# Altium schematic sheet Sch_Harvesting.SchDoc
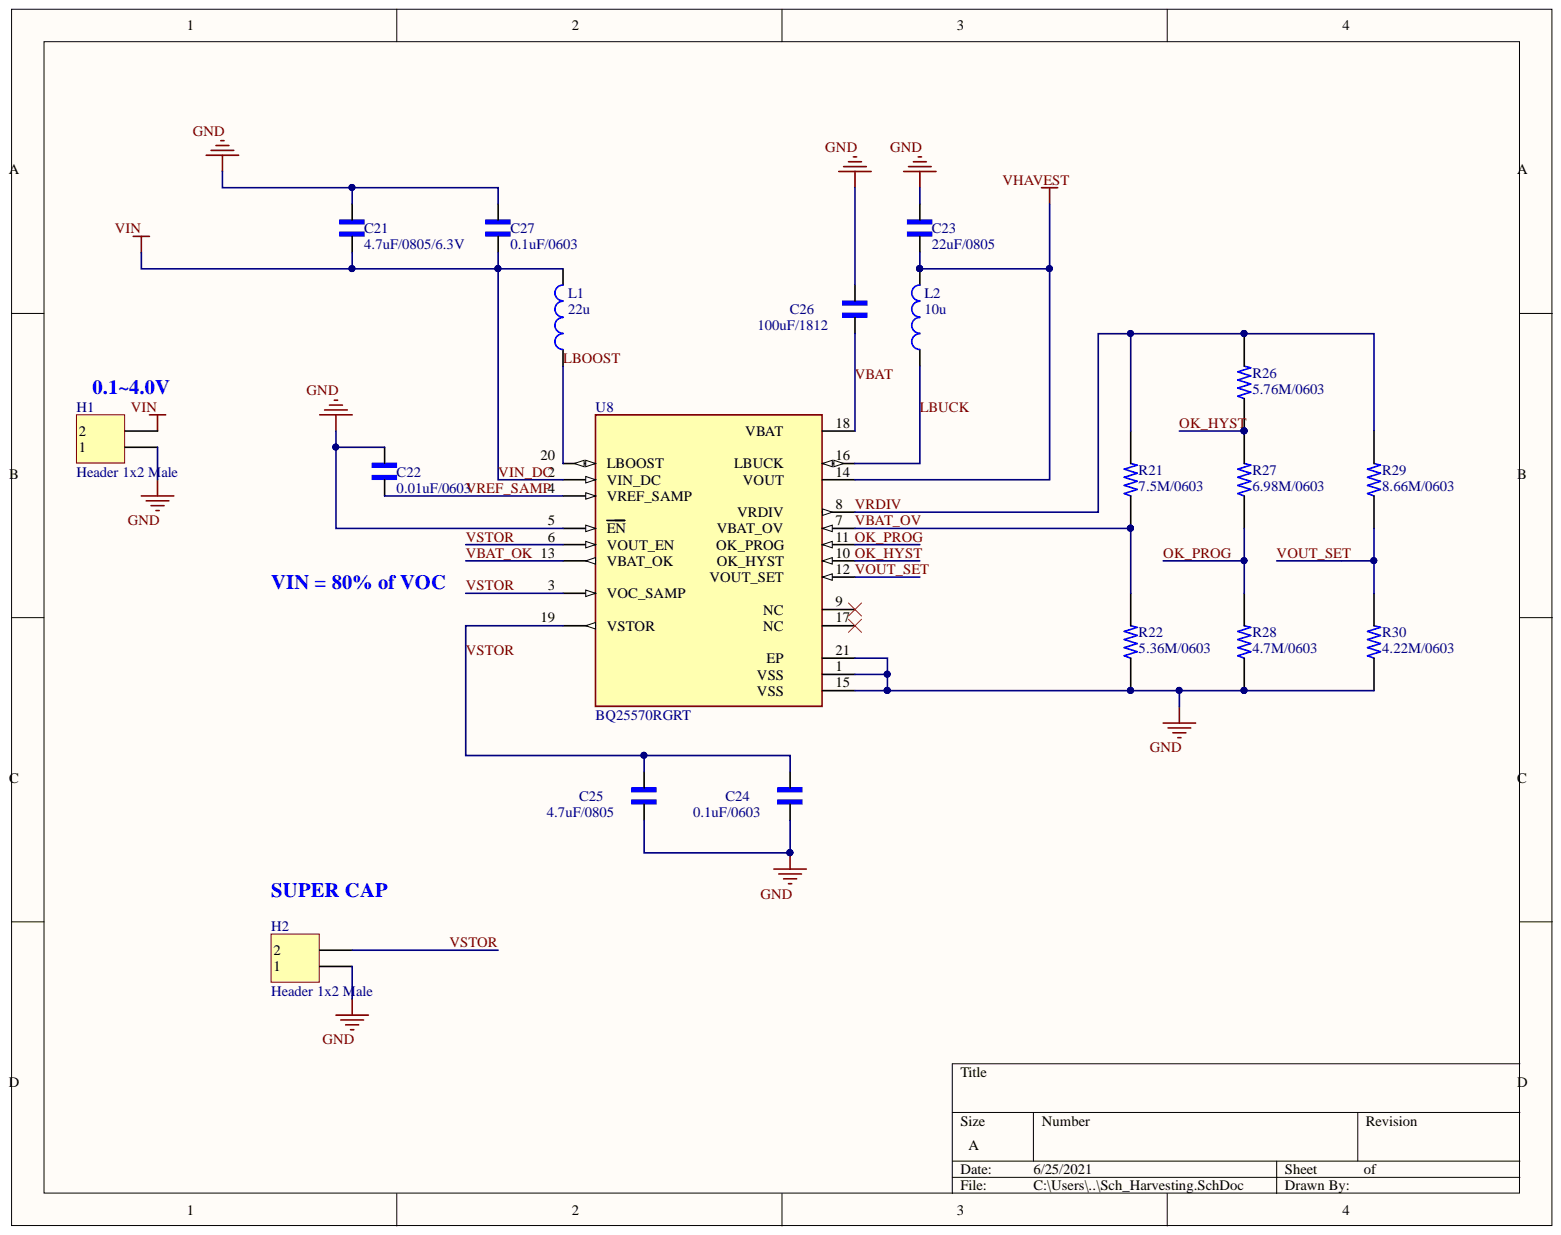
[diagram p0: Sch_Harvesting.SchDoc]

## Parts
C21  4.7uF/0805/6.3V  [CAP-0805]
C22  0.01uF/0603  [CAP-0603]
C23  22uF/0805  [CAP-0805]
C24  0.1uF/0603  [CAP-0603]
C25  4.7uF/0805  [CAP-0805]
C26  100uF/1812  [CAP-1812]
C27  0.1uF/0603  [CAP-0603]
H1  Header 1x2 Male  [HDR1X2]
H2  Header 1x2 Male  [HDR1X2]
L1  value 22u  [IND-40x18]  (hidden comment: Inductor)
L2  value 10u  [IND-25x20]  (hidden comment: Inductor)
R21  7.5M/0603  [RES-0603]
R22  5.36M/0603  [RES-0603]
R26  5.76M/0603  [RES-0603]
R27  6.98M/0603  [RES-0603]
R28  4.7M/0603  [RES-0603]
R29  8.66M/0603  [RES-0603]
R30  4.22M/0603  [RES-0603]
U8  BQ25570RGRT  [VQFN-20]

## Nets
GND: C21.1 C22.1 C23.1 C24.2 C25.2 C26.1 C27.1 H1.1 H2.1 R22.1 R28.1 R30.1 U8.1 U8.5(EN) U8.15 U8.21
VHAVEST: C23.2 L2.2 U8.14
LBOOST: L1.1 U8.20(LBOOST)
LBUCK: L2.1 U8.16
OK_HYST: R26.1 R27.2 U8.10
OK_PROG: R27.1 R28.2 U8.11
VBAT: C26.2 U8.18
VBAT_OK: U8.13(VBAT_OK)
VBAT_OV: R21.1 R22.2 U8.7
VIN_DC: C21.2 C27.2 H1.2 L1.2 U8.2(VIN_DC)
VOUT_SET: R29.1 R30.2 U8.12
VRDIV: R21.2 R26.2 R29.2 U8.8
VREF_SAMP: C22.2 U8.4(VREF_SAMP)
VSTOR: C24.1 C25.1 H2.2 U8.3(VOC_SAMP) U8.6(VOUT_EN) U8.19(VSTOR)

Not connected: U8 9 17

## Library data of the parts
C21-C27 = Cap 0603: Ceramic Capacitor; MF: AVX; MP: LD031A330JAB2A_0; Availability: Unavailable; Component Kind: Standard; Package: 0603 AVX; Price: None; SnapEDA_Link: https://www.snapeda.com/parts/LD031A330JAB2A%5C500/AVX/view-part/2029942/?ref=snap; Library Name: tuandang.SchLib
H1 H2 = Header 2: Header, 2-Pin; Component Kind: Standard; LatestRevisionDate: 17-Jul-2002; LatestRevisionNote: Re-released for DXP Platform.; Library Name: Miscellaneous Connectors.IntLib; Signal Integrity: Connector; Publisher: Altium Limited
L1 L2 = Inductor: SimulationGenericComponent: True; Component Kind: Standard; Description: Inductor; Library Name: Simulation Generic Components.IntLib
R21 = Resistor 0603: CRG0603 1% 4K75; MP: 1-1622960-0; Value: 7.5M; Availability: Unavailable; Component Kind: Standard; Package: None; Price: None; Library Name: tuandang.SchLib
R22 R26-R30 = Resistor 0603: CRG0603 1% 4K75; MP: 1-1622960-0; Availability: Unavailable; Component Kind: Standard; Package: None; Price: None; Library Name: tuandang.SchLib
U8 = BQ25570RGRT: Ultra Low power Harvester power Management IC with boost charger, and Nanopower Buck Converter 20-VQFN -40 to 125; MF: Texas Instruments; Availability: Warning; Check_prices: https://www.snapeda.com/parts/BQ25570RGRT/Texas%20Instruments/view-part/49255/?ref=eda; Component Kind: Standard; MP: BQ25570RGRT; Package: VQFN-20 Texas Instruments; Price: None; Purchase-URL: https://pricing.snapeda.com/search/part/BQ25570RGRT/?ref=eda; SnapEDA_Link: https://www.snapeda.com/parts/BQ25570RGRT/Texas%20Instruments/view-part/49255/?ref=snap; Library Name: tuandang.SchLib
